# Altium schematic sheet UD3.1.SchDoc
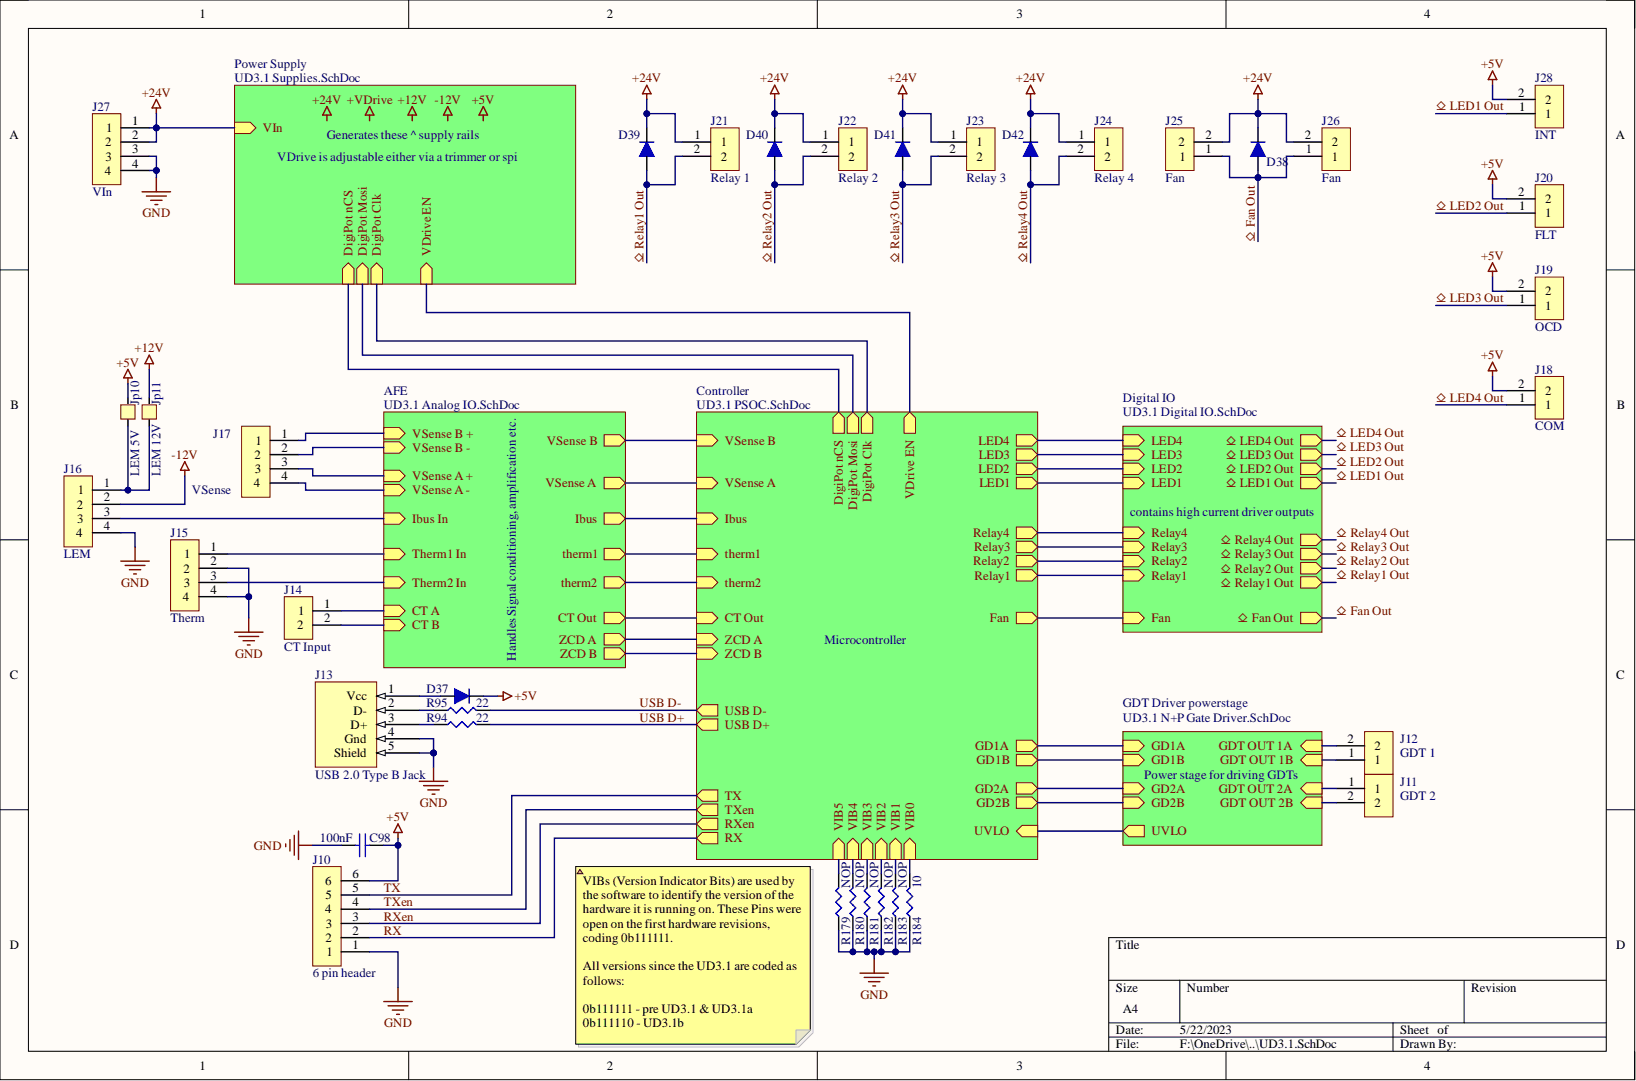
[diagram p0: UD3.1.SchDoc]

## Parts
C98  100nF  [0603]
D37  Schottky 30V 1A  [DO-214]  (value not drawn)
D38  Schottky 30V 1A  [DO-214]  (value not drawn)
D39  Schottky 30V 100mA  [SOD523]  (value not drawn)
D40  Schottky 30V 100mA  [SOD523]  (value not drawn)
D41  Schottky 30V 100mA  [SOD523]  (value not drawn)
D42  Schottky 30V 100mA  [SOD523]  (value not drawn)
J10  6 pin header  [6 KK254 / KF2510]
J11  GDT 2  [2 KK254 / KF2510]
J12  GDT 1  [2 KK254 / KF2510]
J13  USB 2.0 Type B Jack  [USB 2.0 Type B Vertical]
J14  CT Input  [2 KK254 / KF2510]
J15  Therm  [4 KK254 / KF2510]
J16  LEM  [4 KK254 / KF2510]
J17  VSense  [4 KK254 / KF2510]
J18  COM  [2 KK254 / KF2510]
J19  OCD  [2 KK254 / KF2510]
J20  FLT  [2 KK254 / KF2510]
J21  Relay 1  [2 KK254 / KF2510]
J22  Relay 2  [2 KK254 / KF2510]
J23  Relay 3  [2 KK254 / KF2510]
J24  Relay 4  [2 KK254 / KF2510]
J25  Fan  [2 KK254 / KF2510]
J26  Fan  [2 screw terminal vertical]
J27  VIn  [4 KK254 / KF2510]
J28  INT  [2 KK254 / KF2510]
Jp10  LEM 5V  [PCB Jumper NO]
Jp11  LEM 12V  [PCB Jumper NO]
R94  22  [0603]
R95  22  [0603]
R179  NOP  [0603]
R180  NOP  [0603]
R181  NOP  [0603]
R182  NOP  [0603]
R183  NOP  [0603]
R184  10  [0603]

## Nets
+5V: C98.1 D37.2 J10.6 J18.2 J19.2 J20.2 J28.2 Jp10.2
+12V: Jp11.2
+24V: D38.2 D39.2 D40.2 D41.2 D42.2 J21.1 J22.1 J23.1 J24.1 J25.2 J26.2 J27.1 J27.2
-12V: J16.2
GND: C98.2 J10.1 J13.4(Gnd) J13.5(Shield) J15.2 J15.4 J16.4 J27.3 J27.4 R179.2 R180.2 R181.2 R182.2 R183.2 R184.2
RX: J10.2
RXen: J10.3
TX: J10.5
TXen: J10.4
USB D+: R94.1
USB D-: R95.1
⎐ Fan Out: D38.1 J25.1 J26.1
⎐ LED1 Out: J28.1
⎐ LED2 Out: J20.1
⎐ LED3 Out: J19.1
⎐ LED4 Out: J18.1
⎐ Relay1 Out: D39.1 J21.2
⎐ Relay2 Out: D40.1 J22.2
⎐ Relay3 Out: D41.1 J23.2
⎐ Relay4 Out: D42.1 J24.2
NetD37_1: D37.1 J13.1(Vcc)
NetJ11_1: J11.1
NetJ11_2: J11.2
NetJ12_1: J12.1
NetJ12_2: J12.2
NetJ13_2: J13.2(D-) R95.2
NetJ13_3: J13.3(D+) R94.2
NetJ14_1: J14.1
NetJ14_2: J14.2
NetJ15_1: J15.1
NetJ15_3: J15.3
NetJ16_1: J16.1 Jp10.1 Jp11.1
NetJ16_3: J16.3
NetJ17_1: J17.1
NetJ17_2: J17.2
NetJ17_3: J17.3
NetJ17_4: J17.4
NetR179_1: R179.1
NetR180_1: R180.1
NetR181_1: R181.1
NetR182_1: R182.1
NetR183_1: R183.1
NetR184_1: R184.1
+VDrive:
CT Out:
DigiPot Clk:
DigiPot Mosi:
DigiPot nCS:
Fan:
GD1A:
GD1B:
GD2A:
GD2B:
Ibus:
LED1:
LED2:
LED3:
LED4:
Relay1:
Relay2:
Relay3:
Relay4:
therm1:
therm2:
UVLO:
VDrive EN:
VSense A:
VSense B:
ZCD A:
ZCD B:

## Library data of the parts
C98 = Cap: Component Kind: Standard; Library Name: stdLib.SCHLIB
D37-D42 = Diode: Cmon its a diode what more do I need to say?; Component Kind: Standard; Library Name: stdLib.SCHLIB; Supplier 1: Digi-Key; Supplier 2: Digi-Key; Supplier Part Number 1: MBR10100GOS-ND; Supplier Part Number 2: 1655-1504-1-ND
J10 = 6 header: Component Kind: Standard; Library Name: stdCon.SchLib
J11 J12 J14 J18-J25 J28 = 2 header: Component Kind: Standard; Library Name: stdCon.SchLib
J13 = USB 2.0 Type B: Component Kind: Standard; Description: USB 2.0 Type B Jack; Library Name: stdCon.SchLib
J15-J17 J27 = 4 header: Component Kind: Standard; Library Name: stdCon.SchLib
J26 = 2 screw terminal: Component Kind: Standard; Library Name: stdCon.SchLib
Jp10 Jp11 = Jumper: Component Kind: Standard; Library Name: stdLib.SCHLIB
R94 R95 R179-R184 = Resistor: Component Kind: Standard; Library Name: stdLib.SCHLIB
